# Altium schematic sheet Sheet2.SchDoc(Sheet2)
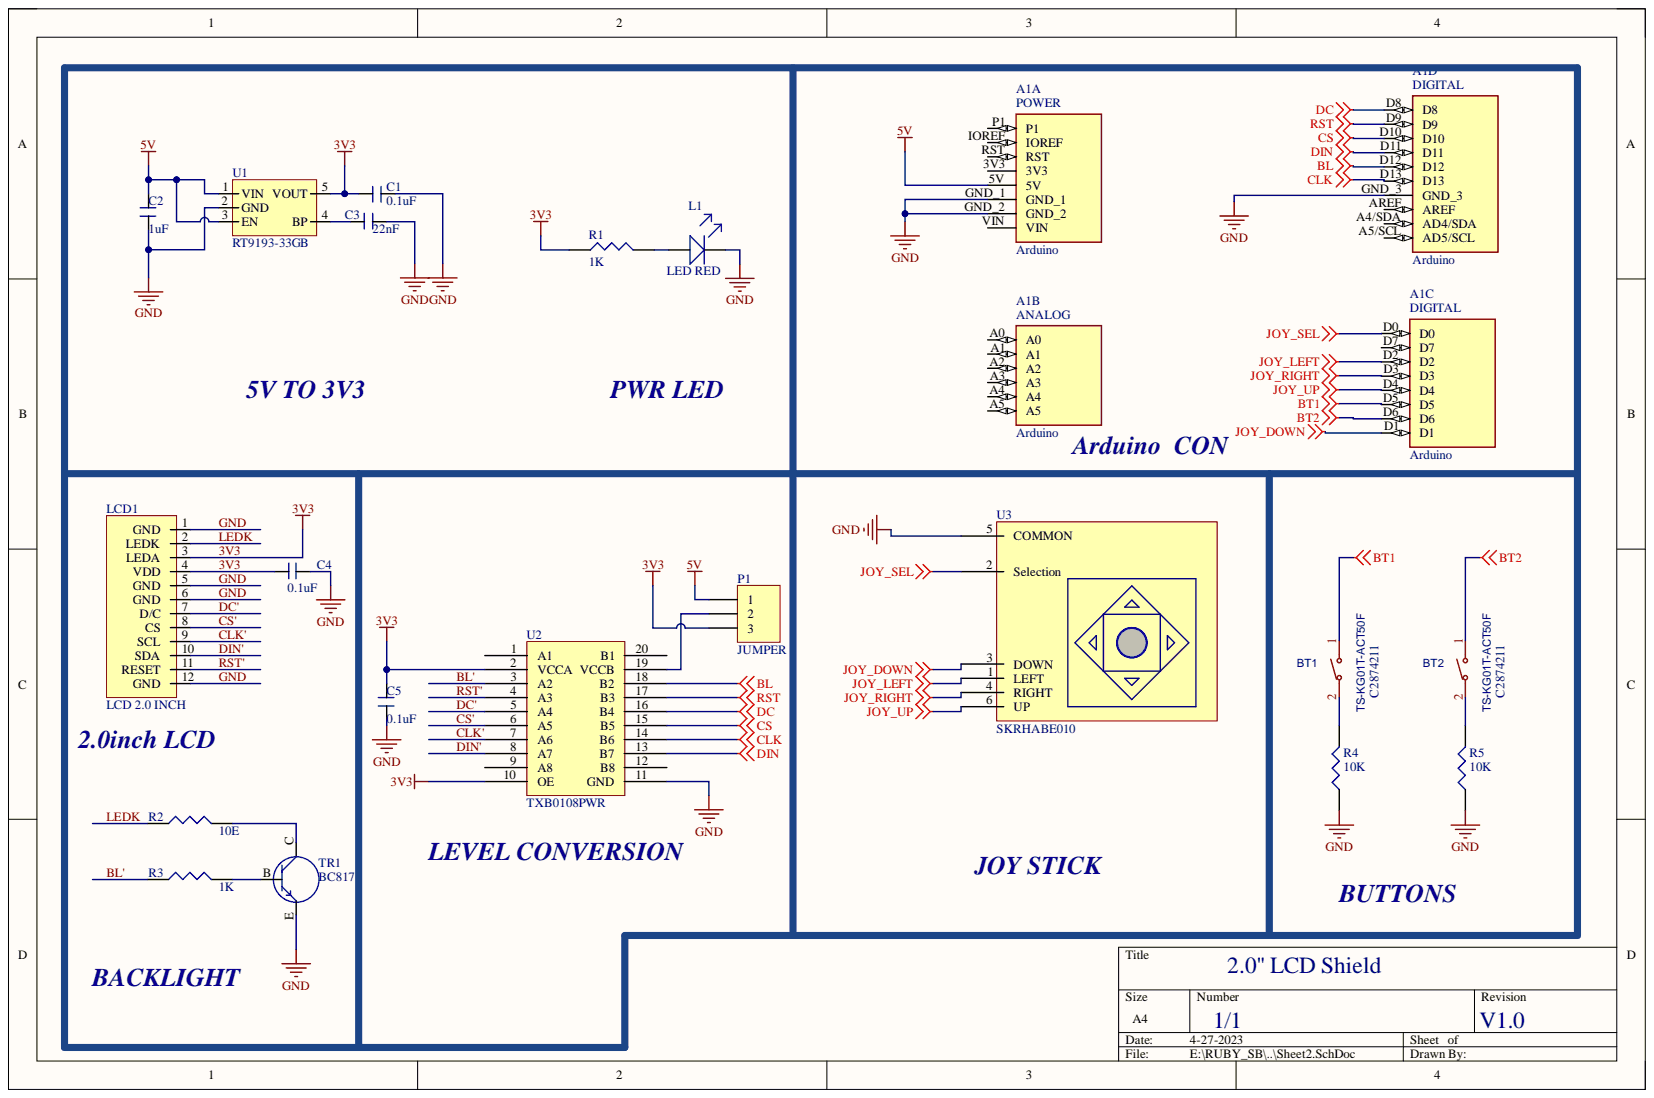
[diagram p0: Sheet2.SchDoc]

## Parts
A1  Arduino  [Arduino]  (parts A,B,C,D)
BT1  TS-KG01T-ACT50F  [TAC 2P SMD]
BT2  TS-KG01T-ACT50F  [TAC 2P SMD]
C1  0.1uF  [C_0603]
C2  1uF  [C_0603]
C3  22nF  [C_0603]
C4  0.1uF  [C_0603]
C5  0.1uF  [C_0603]
L1  LED RED  [LED_0603]
LCD1  LCD 2.0 INCH  [2.0 INCH LCD]
P1  JUMPER  [HDR1X3]
R1  1K  [R_0603]
R2  10E  [R_0603]
R3  1K  [R_0603]
R4  10K  [R_0603]
R5  10K  [R_0603]
TR1  BC817  [SOT23]
U1  RT9193-33GB  [SOT23-5]
U2  TXB0108PWR  [TSSOP 20P]
U3  SKRHABE010  [JOY_STICK]

## Nets
5V: A1.5V C2.1 P1.1 U1.1(VIN) U1.3(EN)
BL: A1.D12 U2.18(B2)
BT1: A1.D5 BT1.1
BT2: A1.D6 BT2.1
CLK: A1.D13 U2.14(B6)
CS: A1.D10 U2.15(B5)
DC: A1.D8 U2.16(B4)
DIN: A1.D11 U2.13(B7)
JOY_DOWN: A1.D1 U3.3(DOWN)
JOY_LEFT: A1.D2 U3.1(LEFT)
JOY_RIGHT: A1.D3 U3.4(RIGHT)
JOY_SEL: A1.D0 U3.2(Selection)
JOY_UP: A1.D4 U3.6(UP)
RST: A1.D9 U2.17(B3)
3V3: C1.1 C4.2 C5.1 LCD1.3(LEDA) LCD1.4(VDD) P1.3 R1.1 U1.5(VOUT) U2.2(VCCA) U2.10(OE)
BL': R3.1 U2.3(A2)
CLK': LCD1.9(SCL) U2.7(A6)
CS': LCD1.8(CS) U2.6(A5)
DC': LCD1.7(D/C) U2.5(A4)
DIN': LCD1.10(SDA) U2.8(A7)
GND: A1.GND_1 A1.GND_2 A1.GND_3 C1.2 C2.2 C3.1 C4.1 C5.2 L1.K LCD1.1(GND) LCD1.5(GND) LCD1.6(GND) LCD1.12(GND) R4.1 R5.1 TR1.E U1.2(GND) U2.11(GND) U3.5(COMMON)
LEDK: LCD1.2(LEDK) R2.1
RST': LCD1.11(RESET) U2.4(A3)
NetBT1_2: BT1.2 R4.2
NetBT2_2: BT2.2 R5.2
NetC3_2: C3.2 U1.4(BP)
NetL1_A: L1.A R1.2
NetP1_2: P1.2 U2.19(VCCB)
NetR2_2: R2.2 TR1.C
NetR3_2: R3.2 TR1.B

Not connected: A1 3V3 A0 A1 A2 A3 A4 A4/SDA(AD4/SDA) A5 A5/SCL(AD5/SCL) AREF D7 IOREF P1 RST VIN; U2 1(A1) 9(A8) 12(B8) 20(B1)

## Library data of the parts
A1 = Arduino: Microcontroller board, Uno [Arduino] A000066 Microcontroller board, Uno 7640152110092 <a href="https://pricing.snapeda.com/parts/A000066/Arduino/view-part?ref=eda">Check prices</a> <a href="https://pricing.snapeda.com/parts/A000066/Arduino/view-part?ref=eda">Check prices</a>; MP: ARDUINO UNO REV3; Availability: Unavailable; Component Kind: Standard; Package: None; Price: None; Library Name: MIX.SchLib
BT1 BT2 = TS-KG01T-ACT50F: BOM_Manufacturer: HANBO(汉博); Contributor: LCSC; BOM_JLCPCB Part Class: Extended Part; BOM_Manufacturer Part: TS-KG01T-ACT50F; BOM_Supplier: LCSC; BOM_Supplier Part: C2874211; Component Kind: Standard; spicePre: S; spiceSymbolName: TS-KG01T-ACT50F
C1-C5 = CAP_NONPOLAR: Component Kind: Standard; Library Name: dxp_pasl_lib.IntLib
L1 = LED: Component Kind: Standard; Library Name: dxp_pasl_lib.IntLib
LCD1 = LCD 1.3INCH: 1.3inch LCD, 240RGDX240 DOT MATIRX TFT LCD MODULE; Component Kind: Standard; Library Name: dxp_pasl_lib.IntLib
P1 = Header 3: Header, 3-Pin; Component Kind: Standard; LatestRevisionDate: 17-Jul-2002; LatestRevisionNote: Re-released for DXP Platform.; Library Name: Miscellaneous Connectors.IntLib; Signal Integrity: Connector; Publisher: Altium Limited
R1-R5 = RES: Component Kind: Standard; Library Name: dxp_pasl_lib.IntLib
TR1 = TRANSISTER_NPN: Component Kind: Standard; Library Name: dxp_pasl_lib.IntLib
U1 = RT9193-33GB: 300mA 70dB@(100Hz),50dB@(10kHz) 300mV@(300mA) Fixed 3.3V~3.3V Positive 1 5.5V SOT-23-5 Linear Voltage Regulators (LDO) ROHS #C15651; Component Kind: Standard; Library Name: dxp_pasl_lib.IntLib
U2 = TXB0108EPWR 2: Level Translators, Shifters RoHS TSSOP-20 # C53406; Component Kind: Standard; Library Name: dxp_pasl_lib.IntLib
U3 = SKRHABE010: SMD 5-way Tactile Switches RoHS #C139794; Component Kind: Standard; Library Name: dxp_pasl_lib.IntLib
